# Altium schematic sheet 3phase_testboard.SchDoc(3phase_testboard)
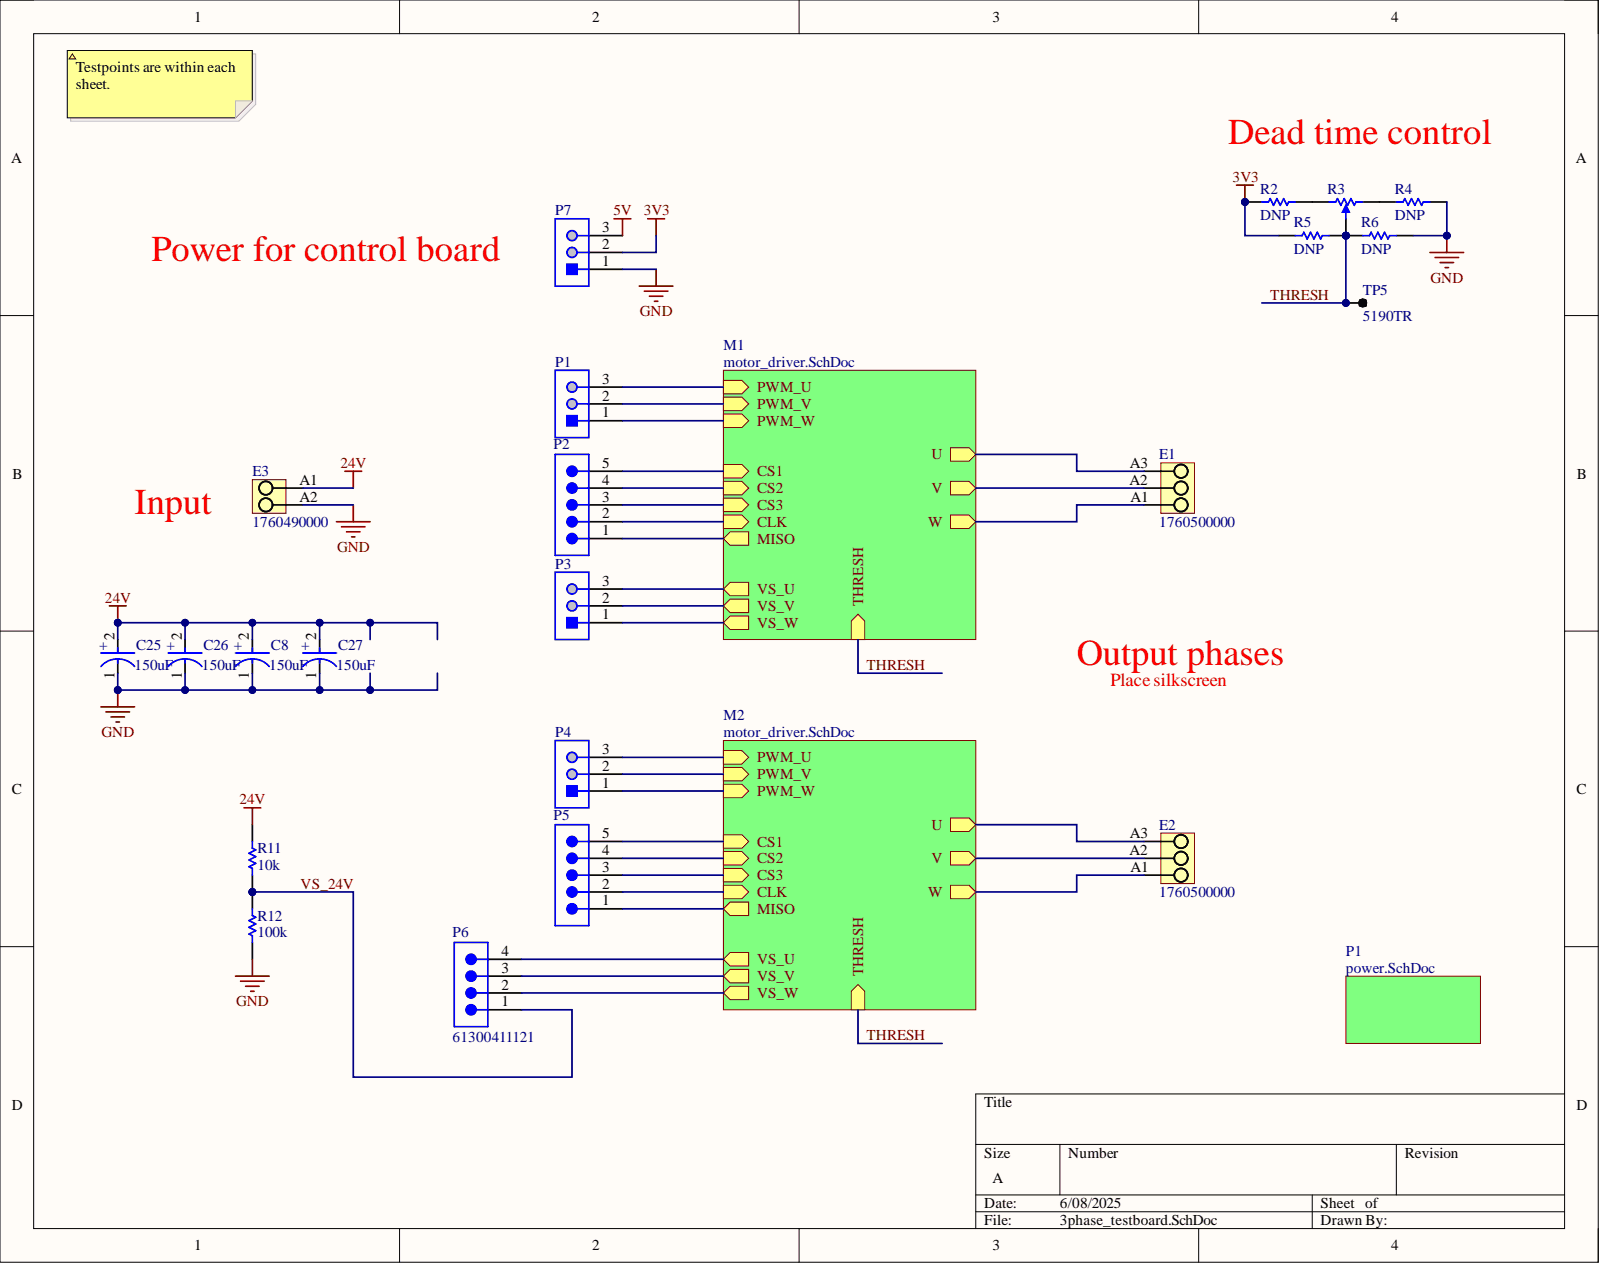
[diagram p0: 3phase_testboard.SchDoc]

## Parts
C8  Capacitance: 150uF  (hidden comment: EEEFTV151XAP)
C25  Capacitance: 150uF  (hidden comment: EEEFTV151XAP)
C26  Capacitance: 150uF  (hidden comment: EEEFTV151XAP)
C27  Capacitance: 150uF  (hidden comment: EEEFTV151XAP)
E1  1760500000
E2  1760500000
E3  1760490000
P1  22-28-4033  (value not drawn)
P2  61300511121  (value not drawn)
P3  22-28-4033  (value not drawn)
P4  22-28-4033  (value not drawn)
P5  61300511121  (value not drawn)
P6  61300411121
P7  22-28-4033  (value not drawn)
R2  DNP
R3  TC33X-2-103E  (value not drawn)
R4  DNP
R5  DNP
R6  DNP
R11  10k
R12  100k
TP5  5190TR

## Nets
3V3: P7.2 R2.1 R5.1
5V: P7.3
24V: C8.2 C25.2 C26.2 C27.2 E3.A1 R11.2
GND: C8.1 C25.1 C26.1 C27.1 E3.A2 P7.1 R4.2 R6.2 R12.1
THRESH: R3.2 R5.2 R6.1 TP5.TP
VS_24V: P6.1 R11.1 R12.2
NetE1_A1: E1.A1
NetE1_A2: E1.A2
NetE1_A3: E1.A3
NetE2_A1: E2.A1
NetE2_A2: E2.A2
NetE2_A3: E2.A3
NetP1_1: P1.1
NetP1_2: P1.2
NetP1_3: P1.3
NetP2_1: P2.1
NetP2_2: P2.2
NetP2_3: P2.3
NetP2_4: P2.4
NetP2_5: P2.5
NetP3_1: P3.1
NetP3_2: P3.2
NetP3_3: P3.3
NetP4_1: P4.1
NetP4_2: P4.2
NetP4_3: P4.3
NetP5_1: P5.1
NetP5_2: P5.2
NetP5_3: P5.3
NetP5_4: P5.4
NetP5_5: P5.5
NetP6_2: P6.2
NetP6_3: P6.3
NetP6_4: P6.4
NetR2_2: R2.2 R3.1
NetR3_3: R3.3 R4.1

## Library data of the parts
C8 C25-C27 = CMP-05427-000048-1: CAP ALUM 150UF 20% 35V SMD; Dielectric Material: Aluminium; ESR (Equivalent Series Resistance): 160mR; Lead Diameter: 0.65mm; Leakage Current: 52.5uA; Life (Hours): 2000Hour; Polarity: Polar; Ripple Current: 600mA; Case/Package: Radial; Component Kind: Standard; Construction: Cylindrical; Contact Plating: Tin; Diameter: 6.3mm; Height: 7.7mm; Height - Seated (Max): 0.315inch; Lead Free: Lead Free; Lead Pitch: 1.8mm; Length: 7.7mm; Library Name: Altium Content Vault; Max Operating Temperature: 105°C; Min Operating Temperature: -55°C; Mount: Surface Mount; Number of Pins: 8; Package Quantity: 900; Packaging: Tape and Reel; Radiation Hardening: No; REACH SVHC: No SVHC; Ripple Current (AC): 600mA; RoHS Compliant: Yes; Series: FT; Termination: SMD/SMT; Tolerance: 20%; Voltage: 35V; Voltage Rating: 35V; Voltage Rating (DC): 35V
E1 E2 = CMP-1559-01629-1: OMNIMATE Signal - series PM; Manufacturer: Weidmueller; Contact_material: Cu-Leg; Contact_surface: tinned; Insulating_material: Wemid (PA); Mounting_onto_the_PCB: Solder connection; No_of_poles: 3; Pitch_inch: 0,2 inch; Pitch_mm: 5,08 mm; Rated_current_use_group_B_UL_1059: 15 A; Rated_voltage_for_surge_voltage_class_pollution_degree_II_2: 600 V; Rated_voltage_use_group_B_UL_1059: 300 V; Short_text_for_material: PM 5.08/03/90 3.5SN OR BX; Side_termination_characteristic: closed side; Solder_pin_length_mm: 3,5 mm; Solid_max_H05_07_V_U: 2,5 mm²; Solid_min_H05_07_V_U: 0,13 mm²; UL_94_flammability_rating: V-0; Wire_cross_section_AWG_max_UL_1059: AWG 14; Wire_cross_section_AWG_min_UL_1059: AWG 26; Colour: Orange; Component Kind: Standard; ComponentLink1Description: Manufacturer URL; ComponentLink1URL: http://www.weidmueller.com/; ComponentLink2Description: URL Link1; ComponentLink2URL: http://catalog.weidmueller.com/catalog/Start.do?localeId=en&ObjectID=1760500000; Library Name: Altium Content Vault; PackageReference: PM_5_08_90_03; Packaging: Box; PartNumber: 1760500000; Qty: 500 PCS; Revision: V1
E3 = CMP-1559-01627-1: OMNIMATE Signal - series PM; Manufacturer: Weidmueller; Contact_material: Cu-Leg; Contact_surface: tinned; Insulating_material: Wemid (PA); Mounting_onto_the_PCB: Solder connection; No_of_poles: 2; Pitch_inch: 0,2 inch; Pitch_mm: 5,08 mm; Rated_current_use_group_B_UL_1059: 15 A; Rated_voltage_for_surge_voltage_class_pollution_degree_II_2: 600 V; Rated_voltage_use_group_B_UL_1059: 300 V; Short_text_for_material: PM 5.08/02/90 3.5SN OR BX; Side_termination_characteristic: closed side; Solder_pin_length_mm: 3,5 mm; Solid_max_H05_07_V_U: 2,5 mm²; Solid_min_H05_07_V_U: 0,13 mm²; UL_94_flammability_rating: V-0; Wire_cross_section_AWG_max_UL_1059: AWG 14; Wire_cross_section_AWG_min_UL_1059: AWG 26; Colour: Orange; Component Kind: Standard; ComponentLink1Description: Manufacturer URL; ComponentLink1URL: http://www.weidmueller.com/; ComponentLink2Description: URL Link1; ComponentLink2URL: http://catalog.weidmueller.com/catalog/Start.do?localeId=en&ObjectID=1760490000; Library Name: Altium Content Vault; PackageReference: PM_5_08_90_02; Packaging: Box; PartNumber: 1760490000; Qty: 500 PCS; Revision: V1
P1 P3 P4 P7 = CMP-002-00077-1: Board Connector, 3 Contact(s), 1 Row(s), Male, Straight, 0.1 inch Pitch, Solder Terminal, Receptacle; Component Kind: Standard; Connector Type: BOARD CONNECTOR; Max Operating Temperature: 75°C; Min Operating Temperature: 0°C; Mounting Technology: Board; RoHS Compliant: Yes; Library Name: Telerobotics
P2 P5 = CMP-1502-01068-3: NexarPartId: 32855526; Connector Type: Male Pin; Contact Resistance: 20mΩ; Current Rating: 3A; Gender: Male; Number of Contacts: 5; Operating Supply Voltage: 250V; Pitch: 2.54mm; Color: Black; Component Kind: Standard; Contact Material: Copper; Contact Mating Length: 5.9944mm; Contact Plating: Gold; Height: 8.89mm; Lead Free: Lead Free; Lead Pitch: 2.54mm; Library Name: Altium Content Vault; Max Operating Temperature: 105°C; Min Operating Temperature: -40°C; Mount: Through Hole; Number of Pins: 5; Number of Positions: 5; Number of Rows: 1; Orientation: Vertical; Packaging: Bulk; REACH SVHC: Unknown; RoHS: Compliant; Termination: Solder; Voltage Rating: 250V
P6 = CMP-1502-01064-3: NexarPartId: 32855522; Connector Type: Male Pin; Contact Resistance: 20mΩ; Current Rating: 3A; Gender: Male; Number of Contacts: 4; Operating Supply Voltage: 250V; Pitch: 2.54mm; Color: Black; Component Kind: Standard; Contact Material: Copper; Contact Mating Length: 5.9944mm; Contact Plating: Gold; Height: 8.89mm; Lead Free: Lead Free; Lead Pitch: 2.54mm; Library Name: Altium Content Vault; Max Operating Temperature: 105°C; Min Operating Temperature: -40°C; Mount: Through Hole; Number of Pins: 4; Number of Positions: 4; Number of Rows: 1; Orientation: Vertical; Packaging: Bulk; REACH SVHC: Unknown; RoHS: Compliant; Termination: Solder; Voltage Rating: 250V
R2 R4-R6 R11 R12 = CMP-1012-00623-3: Chip Resistor, 10 KOhm, +/- 1%, 0.1 W, -55 to 155 degC, 0603 (1608 Metric), RoHS, Tape and Reel; Manufacturer: Panasonic; Case\/Package: 0603; Max Power Dissipation: 100mW; Resistance: 10KOhm; Temperature Coefficient: 100ppm\/degreesC; Voltage Rating: 75V; Case Code (Imperial): 0603; Case Code (Metric): 1608; Component Kind: Standard; Composition: ThickFilm; Contact Plating: Tin; Datasheet URL: https://industrial.panasonic.com/cdbs/www-data/pdf/RDA0000/AOA0000C304.pdf; Datasheet Version: 02/2017; Depth: 0.8mm; Height: 0.45mm; Lead Free: LeadFree; Length: 1.6mm; Library Name: Altium Content Vault; Manufacturer URL: http://www.panasonic.com/; Max Operating Temperature: 155degC; Military Standard: Not; Min Operating Temperature: -55degC; Mount: SurfaceMount; Mounting Technology: SurfaceMount; Number of Pins: 2; Number of Terminations: 2; Package Description: 2-Pin Surface Mount Device, Body 1.6 x 0.85 mm; Package Quantity: 1; Package Reference: 603; Packaging: TapeandReel; Power Rating: 100mW; Radiation Hardening: No; REACH SVHC: NoSVHC; RoHSCompliant: true; Termination: SMD/SMT; Tolerance: 1%; Voltage Rating (DC): 75V; Width: 0.031inch
R3 = CMP-009-00060-2: Trimming Potentiometer, 10000 Ohm, -40 to 100 degC, 3-Pin SMD, RoHS, Tape and Reel; Manufacturer: Bourns; Power: 100mW; Resistance: 10k; Tolerance: 25%; Case/Package: TC33X-2; Component Kind: Standard; Composition: Potentiometer; Datasheet URL: http://www.bourns.com/docs/Product-Datasheets/TC33.pdf; Datasheet Version: Aug-16; Manufacturer URL: http://www.bourns.com/; Max Operating Temperature: 120°C; Min Operating Temperature: -40°C; Mounting Technology: SM; Package Description: 3-Pin Surface Mount Device, Body 3.8 x 3.6 mm; Package Quantity: 2500; Package Reference: TC33X-2; Packaging: TapeandReel; RoHS Compliant: True; Library Name: Telerobotics
TP5 = CMP-00030-00001-2: Color Keyed SMT Test Point -Red; MF: Keystone Electronics; Case/Package: None; Check_prices: https://www.snapeda.com/parts/5190TR/Keystone+Electronics/view-part/?ref=eda; Component Kind: Standard; Component Type: Standard; Design Item ID: 5190TR; MP: 5190TR; Pins: 1; SnapEDA_Link: https://www.snapeda.com/parts/5190TR/Keystone+Electronics/view-part/?ref=snap; Source: 5190TR.SchLib; Library Name: Telerobotics
